# Altium schematic sheet 02_USB2UART.SchDoc(02_USB2UART)
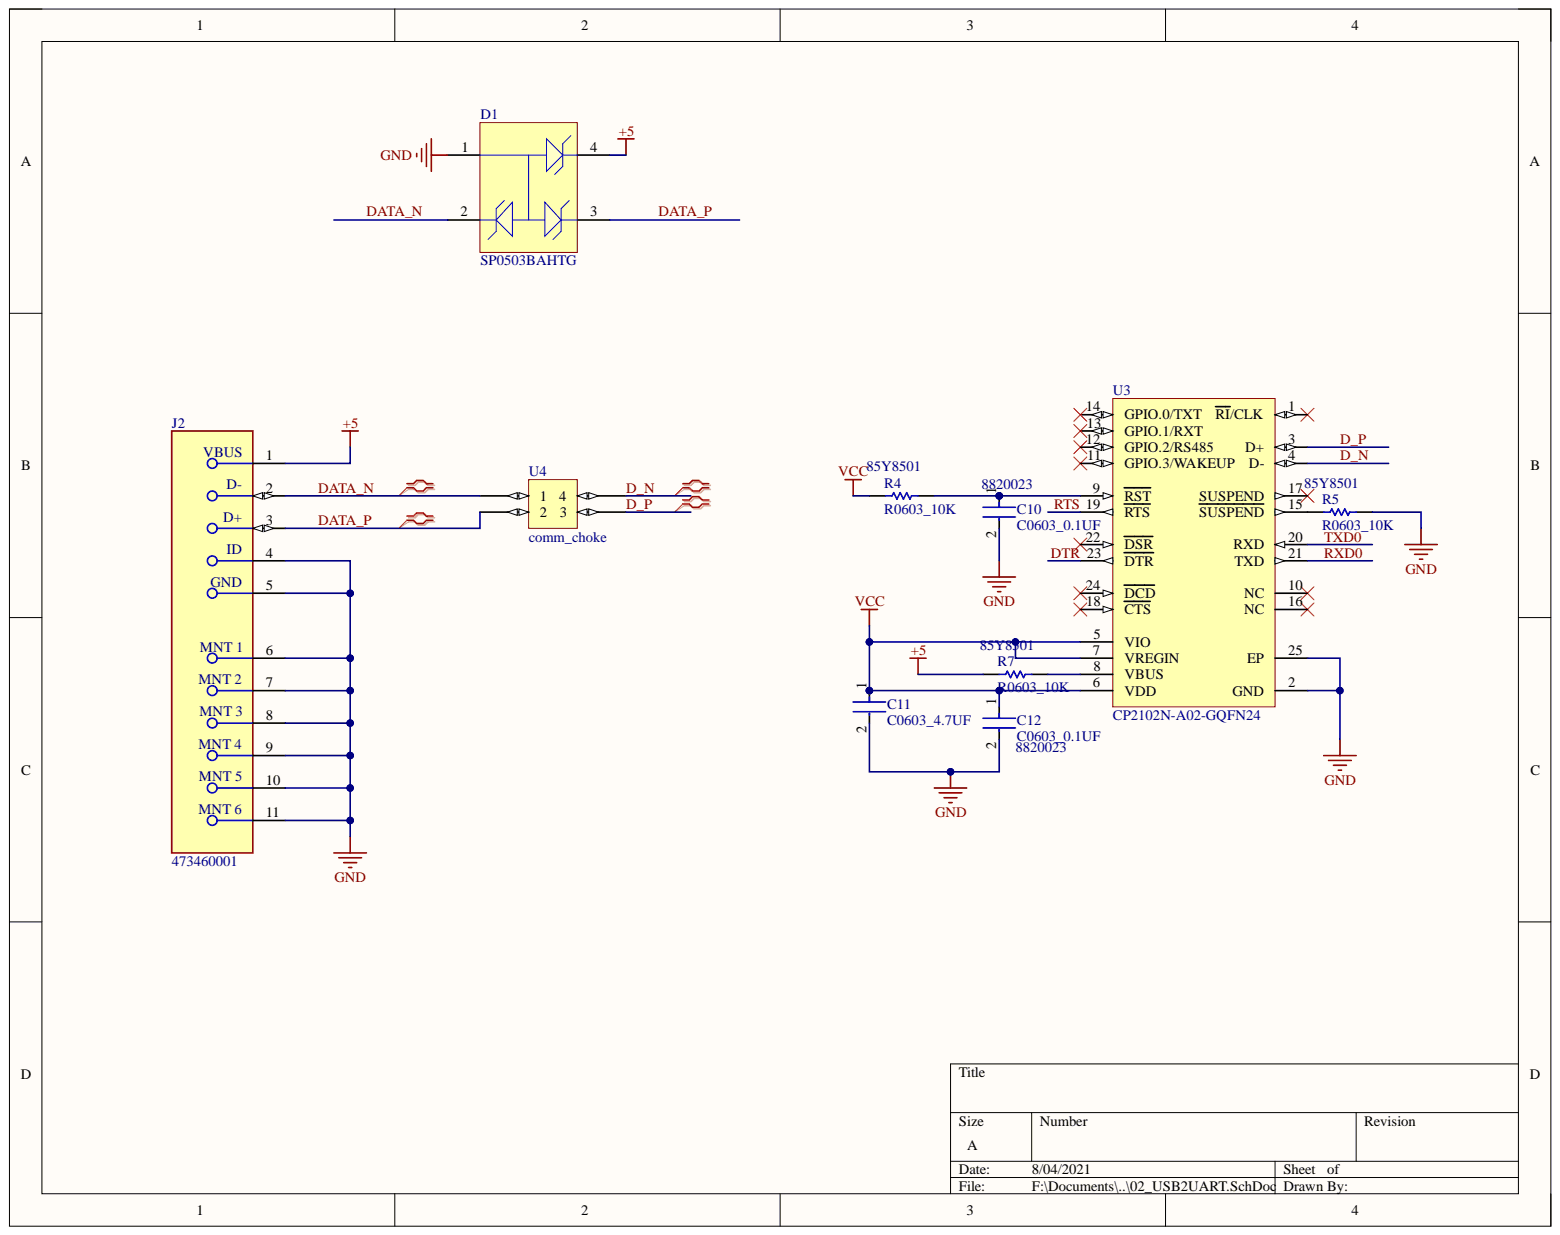
[diagram p0: 02_USB2UART.SchDoc]

## Parts
C10  C0603_0.1UF  Supplier Part Number 1: 8820023  [FP-C0603C-CF-IPC_B]
C11  C0603_4.7UF  [FP-C0603C-CF-IPC_B]
C12  C0603_0.1UF  Supplier Part Number 1: 8820023  [FP-C0603C-CF-IPC_B]
D1  SP0503BAHTG  [LFUS-SOT143-4_V]
J2  473460001  [FP-473460001-MFG]
R4  R0603_10K  Supplier Part Number 1: 85Y8501  [RESC1609X55X30LL15T15]
R5  R0603_10K  Supplier Part Number 1: 85Y8501  [RESC1609X55X30LL15T15]
R7  R0603_10K  Supplier Part Number 1: 85Y8501  [RESC1609X55X30LL15T15]
U3  CP2102N-A02-GQFN24  [SLAB-QFN-24-2550x2550TP_A_V]
U4  comm_choke  [EXC14CE900U]

## Nets
+5: D1.4 J2.1 R7.1
GND: C10.2 C11.2 C12.2 D1.1 J2.4 J2.5 J2.6 J2.7 J2.8 J2.9 J2.10 J2.11 R5.2 U3.2(GND) U3.25(EP)
VCC: C11.1 C12.1 R4.1 U3.5(VIO) U3.6(VDD) U3.7(VREGIN)
D_N: U3.4(D-) U4.4
D_P: U3.3(D+) U4.3
DATA_N: D1.2 J2.2 U4.1
DATA_P: D1.3 J2.3 U4.2
DTR: U3.23(DTR)
RTS: U3.19(RTS)
RXD0: U3.21(TXD)
TXD0: U3.20(RXD)
NetC10_1: C10.1 R4.2 U3.9(RST)
NetR5_1: R5.1 U3.15(SUSPEND)
NetR7_2: R7.2 U3.8(VBUS)

Not connected: U3 1(RI/CLK) 10(NC) 11(GPIO.3/WAKEUP) 12(GPIO.2/RS485) 13(GPIO.1/RXT) 14(GPIO.0/TXT) 16(NC) 17(SUSPEND) 18(CTS) 22(DSR) 24(DCD)

## Library data of the parts
C10 C12 = C0603: Multilayer Ceramic Capacitors MLCC Surface Mount 0603 0.1uF 50 Volts X7R 10%; Manufacturer: Murata; Manufacturer Part Number: GRM188R71H104KA93D; Capacitance: 100nF; Insulation Resistance: 10GR; Voltage: 50V; Case Code (Imperial): 0603; Case Code (Metric): 1608; Case/Package: 0603; Category: Ceramic Capacitors; Component Kind: Standard; ComponentLink1Description: https://datasheet.ciiva.com/19467/1857604-19467681.pdf?src-supplier=Jameco; ComponentLink1URL: https://datasheet.ciiva.com/19467/1857604-19467681.pdf?src-supplier=Jameco; ComponentLink2Description: https://datasheet.ciiva.com/11786/0900766b807a1cab-11786047.pdf?src-supplier=RSComponents; ComponentLink2URL: https://datasheet.ciiva.com/11786/0900766b807a1cab-11786047.pdf?src-supplier=RSComponents; ComponentLink3Description: https://datasheet.ciiva.com/29201/451744-da-01-en-vs-kondensator-0603-29201904.pdf?src-supplier=Conrad; ComponentLink3URL: https://datasheet.ciiva.com/29201/451744-da-01-en-vs-kondensator-0603-29201904.pdf?src-supplier=Conrad; ComponentLink4Description: https://datasheet.ciiva.com/131/partnumbering-e-01-131738.pdf?src-supplier=Digi-Key; ComponentLink4URL: https://datasheet.ciiva.com/131/partnumbering-e-01-131738.pdf?src-supplier=Digi-Key; ComponentLink5Description: https://datasheet.ciiva.com/131/partnumbering-e-01-131739.pdf?src-supplier=Digi-Key; ComponentLink5URL: https://datasheet.ciiva.com/131/partnumbering-e-01-131739.pdf?src-supplier=Digi-Key; ComponentLink6Description: https://datasheet.ciiva.com/131/partnumbering-e-01-131740.pdf?src-supplier=Digi-Key; ComponentLink6URL: https://datasheet.ciiva.com/131/partnumbering-e-01-131740.pdf?src-supplier=Digi-Key; ComponentLink7Description: https://datasheet.ciiva.com/48436/nmgrm-data-en-48436667.pdf?src-supplier=Distrelec; ComponentLink7URL: https://datasheet.ciiva.com/48436/nmgrm-data-en-48436667.pdf?src-supplier=Distrelec; ComponentLink8Description: https://datasheet.ciiva.com/14116/nmgrm-data-en-14116602.pdf?src-supplier=Distrelec; ComponentLink8URL: https://datasheet.ciiva.com/14116/nmgrm-data-en-14116602.pdf?src-supplier=Distrelec; ComponentLink9Description: https://datasheet.ciiva.com/28534/4360-28534245.pdf?src-supplier=Element14; ComponentLink9URL: https://datasheet.ciiva.com/28534/4360-28534245.pdf?src-supplier=Element14; ComponentLink10Description: https://datasheet.ciiva.com/7937/4360-7937659.pdf?src-supplier=Element14; ComponentLink10URL: https://datasheet.ciiva.com/7937/4360-7937659.pdf?src-supplier=Element14; ComponentLink11Description: https://datasheet.ciiva.com/7937/1747470-7937658.pdf?src-supplier=Element14; ComponentLink11URL: https://datasheet.ciiva.com/7937/1747470-7937658.pdf?src-supplier=Element14; Contact Plating: Tin,Matte; Depth: 0.8mm; Dielectric: X7R; Dielectric Material: Ceramic; Height: 0.8mm; Lead Free: Lead Free; Length: 1.6mm; Material: Ceramic; Max Operating Temperature: 125°C; Min Operating Temperature: -55°C; Mount: Surface Mount; Number of Pins: 2; Package Quantity: 1; Packaging: Tape and Reel; Pricing 1: 10=0.04520, 100=0.02000, 500=0.01550, 1000=0.01360, 2000=0.01130, 4000=0.00980, 20000=0.00730, 40000=0.00720 (GBP); Radiation Hardening: No; REACH SVHC: No SVHC; RoHS: Yes; RoHS Compliant: Yes; Supplier 1: Farnell; Termination: SMD/SMT; Thickness: 0.035inch; Tolerance: 10%; Voltage Rating: 50V; Voltage Rating (DC): 50V; Width: 0.8mm; Library Name: RBS_library.IntLib
C11 = C0603: CAP CER 4.7UF 0603; Manufacturer: Murata; Manufacturer Part Number: GRM155R60J475ME47D; Dielectric: X5R; Tolerance: 20%; Voltage: 6.3V; Capacitance: 4.7uF; Case Code (Imperial): 0402; Case Code (Metric): 1005; Case/Package: 0402; Category: Ceramic Capacitors; Component Kind: Standard; ComponentLink1Description: https://datasheet.ciiva.com/6270/product-6270483.pdf?src-supplier=Digi-Key; ComponentLink1URL: https://datasheet.ciiva.com/6270/product-6270483.pdf?src-supplier=Digi-Key; ComponentLink2Description: https://datasheet.ciiva.com/6268/partnumbering-e-01-6268378.pdf?src-supplier=Digi-Key; ComponentLink2URL: https://datasheet.ciiva.com/6268/partnumbering-e-01-6268378.pdf?src-supplier=Digi-Key; ComponentLink3Description: https://datasheet.ciiva.com/6268/partnumbering-e-01-6268382.pdf?src-supplier=Digi-Key; ComponentLink3URL: https://datasheet.ciiva.com/6268/partnumbering-e-01-6268382.pdf?src-supplier=Digi-Key; ComponentLink4Description: https://datasheet.ciiva.com/46418/1769893-46418045.pdf?src-supplier=Element14; ComponentLink4URL: https://datasheet.ciiva.com/46418/1769893-46418045.pdf?src-supplier=Element14; ComponentLink5Description: https://datasheet.ciiva.com/46418/1769893-46418044.pdf?src-supplier=Element14; ComponentLink5URL: https://datasheet.ciiva.com/46418/1769893-46418044.pdf?src-supplier=Element14; Contact Plating: Tin; Depth: 0.5mm; Height: 0.5mm; Lead Free: Lead Free; Length: 1mm; Max Operating Temperature: 85°C; Min Operating Temperature: -55°C; Mount: Surface Mount; Package Quantity: 10000; Packaging: Cut Tape; Pricing 1: 10000=0.01960, 20000=0.01861, 40000=0.01762, 60000=0.01663, 80000=0.01564, 100000=0.01465, 1000000=0.01366 (USD); REACH SVHC: No SVHC; RoHS: Yes; RoHS Compliant: Yes; Series: GRM; Stock 1: 420000; Termination: Standard; Thickness: 0.022inch; Voltage Rating: 6.3V; Voltage Rating (DC): 6.3V; Weight: 0.000212oz; Width: 0.5mm; Library Name: RBS_library.IntLib
D1 = CMP-2000-05191-1: TVS Diode Array, 30 pF, 30 KV, -40 to 85 degC, 4-Pin SOT143, RoHS, Tape and Reel; Manufacturer: Littelfuse; Capacitance Value: 30pF; Clamping Voltage: 8V; Configuration: Triple; Direction Type: Uni-Directional; Leakage Current: 0.1uA; Number of Elements: 3; Product Depth (mm): 1.4mm; Product Height (mm): 1.05mm; Product Length (mm): 3.04mm; Rad Hardened: No; Reverse Stand-off Voltage: 5.5(Min)V; Working Voltage: 5.5V; Working Voltage (Max): 5.5V(Min); Clamping Voltage (Max): 8V; Component Kind: Standard; Datasheet URL: https://datasheet.ciiva.com/15143/getdatasheetpartid-116020-15143550.pdf; Datasheet Version: Dec-13; Leakage Current (Max): 0.1uA; Library Name: Altium Content Vault; Manufacturer URL: http://www.littelfuse.com/; Mounting: SurfaceMount; Mounting Style: SurfaceMount; Operating Temp Range: -40Cto85C; Operating Temperature Classification: Industrial; Operating Temperature Max Deg. C: 85C; Operating Temperature Min Deg. C: -40C; Package / Case: SOT-143; Package Type: SOT-143; Packaging: TapeandReel; Pin Count: 3+Tab; Polarity: Uni-Directional; Suppressor Type: TVS
J2 = CMP-2000-05246-2: CONN RCPT USB2.0 MICRO B SMD R/A; Manufacturer: Molex; Conn Orientation: Right Angle; Current Rating: 1.8A; Is Female: yes; Number Of Contacts: 5; Pitch: 0.65mm; Shielding: true; Voltage Rating: 30V; Automotive: No; Category: Conn; Component Kind: Standard; ComponentLink2Description: Datasheet; ComponentLink2URL: https://www.molex.com/webdocs/datasheets/pdf/en-us/0473460001_IO_CONNECTORS.pdf; Contact Material: Gold,Nickel; Device Class L1: Connectors; Device Class L2: USB Connectors; Device Class L3: unset; Digikey Description: CONN RCPT USB2.0 MICRO B SMD R/A; Footprint Url: https://www.molex.com/pdm_docs/sd/473460001_sd.pdf; Height: 2.945mm; Lead Free: Yes; Library Name: Altium Content Vault; Manufacturer Part Number: 473460001; Octopart: https://octopart.com/47346-0001-molex-7164928; Package: 0; RoHS: TRUE; Temperature: -30°C to +85°C; Temperature Range High: +85°C; Temperature Range Low: -30°C; Usb Standard: USB 2.0
R4 R5 R7 = R0603: PANASONIC - ERJ-3EKF1002V. - THICK FILM CHIP RES, 0603, 1%, PRECISION; Max Power Dissipation: 100mW; Resistance: 10kR; Temperature Coefficient: 100ppm/°C; Voltage Rating: 75V; Case Code (Imperial): 0603; Case Code (Metric): 1608; Case/Package: 0603; Category: Chip SMD Resistors; Component Kind: Standard; ComponentLink1Description: https://datasheet.ciiva.com/48530/aoa0000ce2-48530988.pdf?src-supplier=Avnet; ComponentLink1URL: https://datasheet.ciiva.com/48530/aoa0000ce2-48530988.pdf?src-supplier=Avnet; ComponentLink2Description: https://datasheet.ciiva.com/24070/aoa0000ce2-24070318.pdf?src-supplier=Mouser; ComponentLink2URL: https://datasheet.ciiva.com/24070/aoa0000ce2-24070318.pdf?src-supplier=Mouser; ComponentLink3Description: https://datasheet.ciiva.com/9194/aoa0000ce2-9194199.pdf?src-supplier=Avnet; ComponentLink3URL: https://datasheet.ciiva.com/9194/aoa0000ce2-9194199.pdf?src-supplier=Avnet; ComponentLink4Description: https://datasheet.ciiva.com/30208/aoa0000ce2-30208985.pdf?src-supplier=Onlinecomponents.com; ComponentLink4URL: https://datasheet.ciiva.com/30208/aoa0000ce2-30208985.pdf?src-supplier=Onlinecomponents.com; ComponentLink5Description: https://datasheet.ciiva.com/16759/70260748-16759807.pdf?src-supplier=Allied+Electronics; ComponentLink5URL: https://datasheet.ciiva.com/16759/70260748-16759807.pdf?src-supplier=Allied+Electronics; ComponentLink6Description: https://datasheet.ciiva.com/7830/aoa0000ce2-7830729.pdf?src-supplier=Element14; ComponentLink6URL: https://datasheet.ciiva.com/7830/aoa0000ce2-7830729.pdf?src-supplier=Element14; ComponentLink7Description: https://datasheet.ciiva.com/28514/426256-28514198.pdf?src-supplier=Element14; ComponentLink7URL: https://datasheet.ciiva.com/28514/426256-28514198.pdf?src-supplier=Element14; ComponentLink8Description: https://datasheet.ciiva.com/46372/1678782-46372725.pdf?src-supplier=Element14; ComponentLink8URL: https://datasheet.ciiva.com/46372/1678782-46372725.pdf?src-supplier=Element14; ComponentLink9Description: https://datasheet.ciiva.com/16511/aoa0000ce2-16511861.pdf?src-supplier=Avnet+Asia; ComponentLink9URL: https://datasheet.ciiva.com/16511/aoa0000ce2-16511861.pdf?src-supplier=Avnet+Asia; ComponentLink10Description: https://datasheet.ciiva.com/17212/aoa0000ce2-17212105.pdf?src-supplier=Avnet+Europe; ComponentLink10URL: https://datasheet.ciiva.com/17212/aoa0000ce2-17212105.pdf?src-supplier=Avnet+Europe; Construction: Rectangular; Contact Plating: Tin; Depth: 0.8mm; Features: Automotive AEC-Q200; Height: 0.45mm; Lead Free: Lead Free; Length: 1.6mm; Manufacturer: Panasonic; Manufacturer Part Number: ERJ-3EKF1002V; Max Operating Temperature: 155°C; Military Standard: Not; Min Operating Temperature: -55°C; Mount: Surface Mount; Number of Pins: 2; Number of Terminations: 2; Package Quantity: 1; Packaging: Tape and Reel; Power Rating: 100mW; Pricing 1: 5000=0.00900, 10000=0.00900 (USD); Radiation Hardening: No; REACH SVHC: No SVHC; Resistance Tolerance: 1%; Resistor Type: Thick Film; RoHS: Yes; RoHS Compliant: Yes; Series: ERJ; Size Code: 0603; Stock 1: 27295001; Supplier 1: Newark; Technology: THICK FILM; Termination: SMD/SMT; Tolerance: 1%; Voltage Rating (DC): 75V; Width: 0.031inch; Library Name: RBS_library.IntLib
U3 = CMP-2000-07570-1: USBXpress(TM) Family, 4 GPIOs, 2.2 to 3.6 V, -40 to 85 degC, 24-Pin QFN, RoHS; Manufacturer: Silicon Labs; Max Supply Voltage: 3.6V; Min Supply Voltage: 3V; Case\/Package: QFN; Component Kind: Standard; Datasheet URL: http://www.silabs.com/documents/public/data-sheets/cp2102n-datasheet.pdf; Datasheet Version: Rev. 1.1, 08/2016; Manufacturer URL: http://www.silabs.com/; Max Operating Temperature: 85degC; Min Operating Temperature: -40degC; Mounting Technology: SurfaceMount; Number of Pins: 24; Package Description: 24-Pin Quad Flat No-lead Package, Body 4 x 4 mm, Pitch 0.5 mm; Package Reference: QFN-24-2550x2550TP_A; REACH SVHC: Unknown; RoHSCompliant: False; Library Name: Finger_module.IntLib
U4 = comm_choke: EXC14CE900U; Component Kind: Standard; Library Name: RBS_library.IntLib
